# Altium schematic sheet 2.HOST INTERFACE.SchDoc
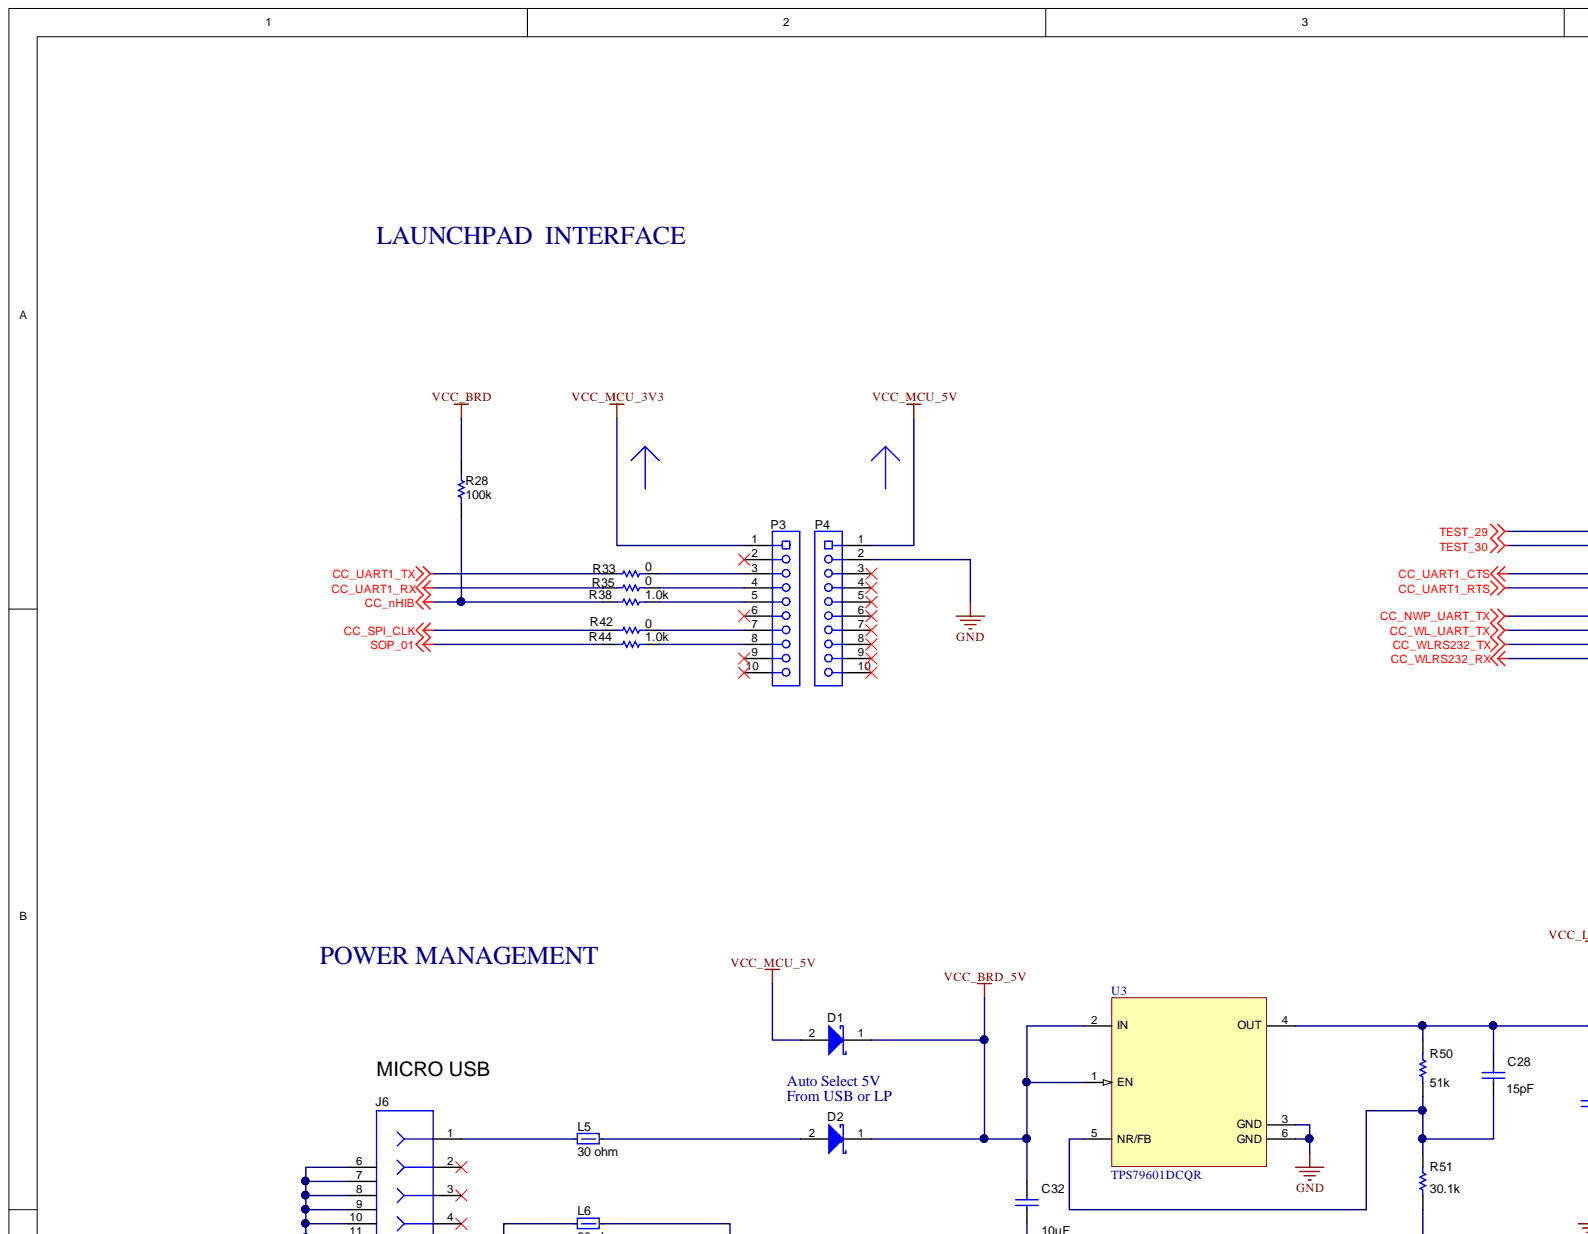
[diagram p0: 2.HOST INTERFACE.SchDoc, top left]
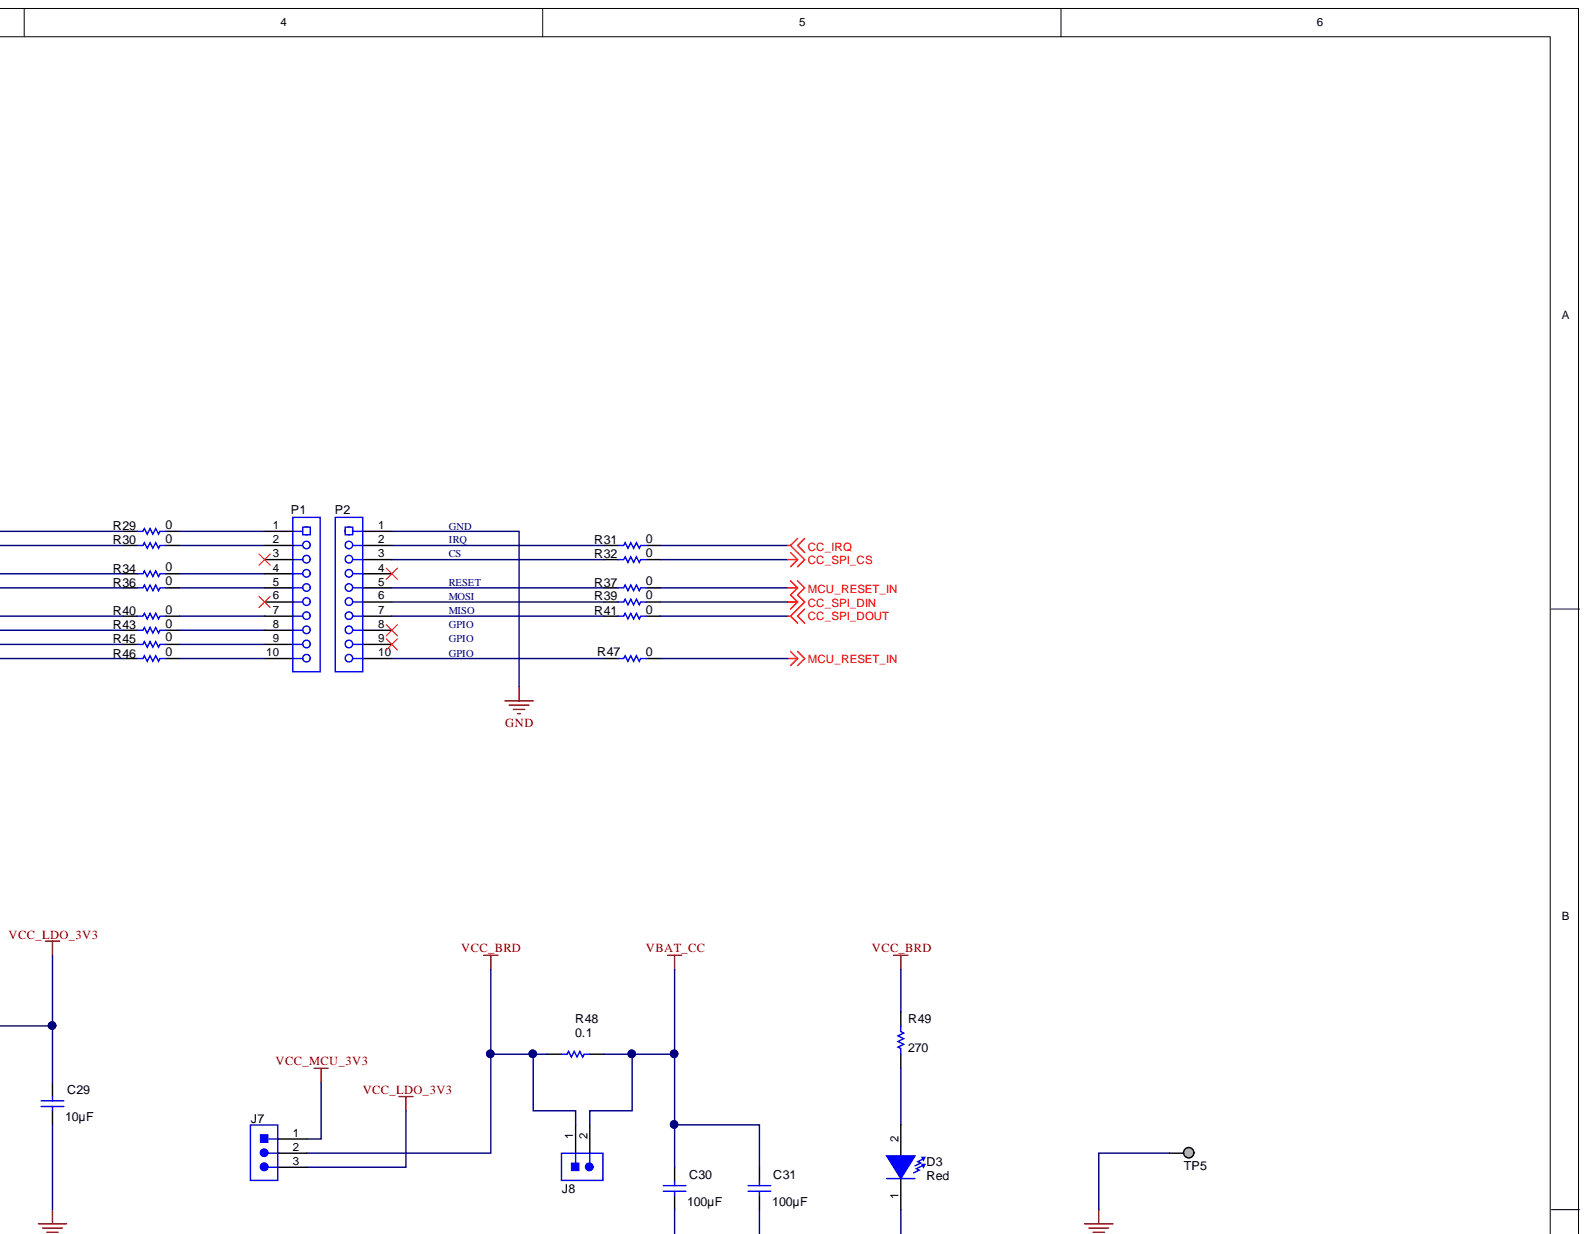
[diagram p1: 2.HOST INTERFACE.SchDoc, top right]
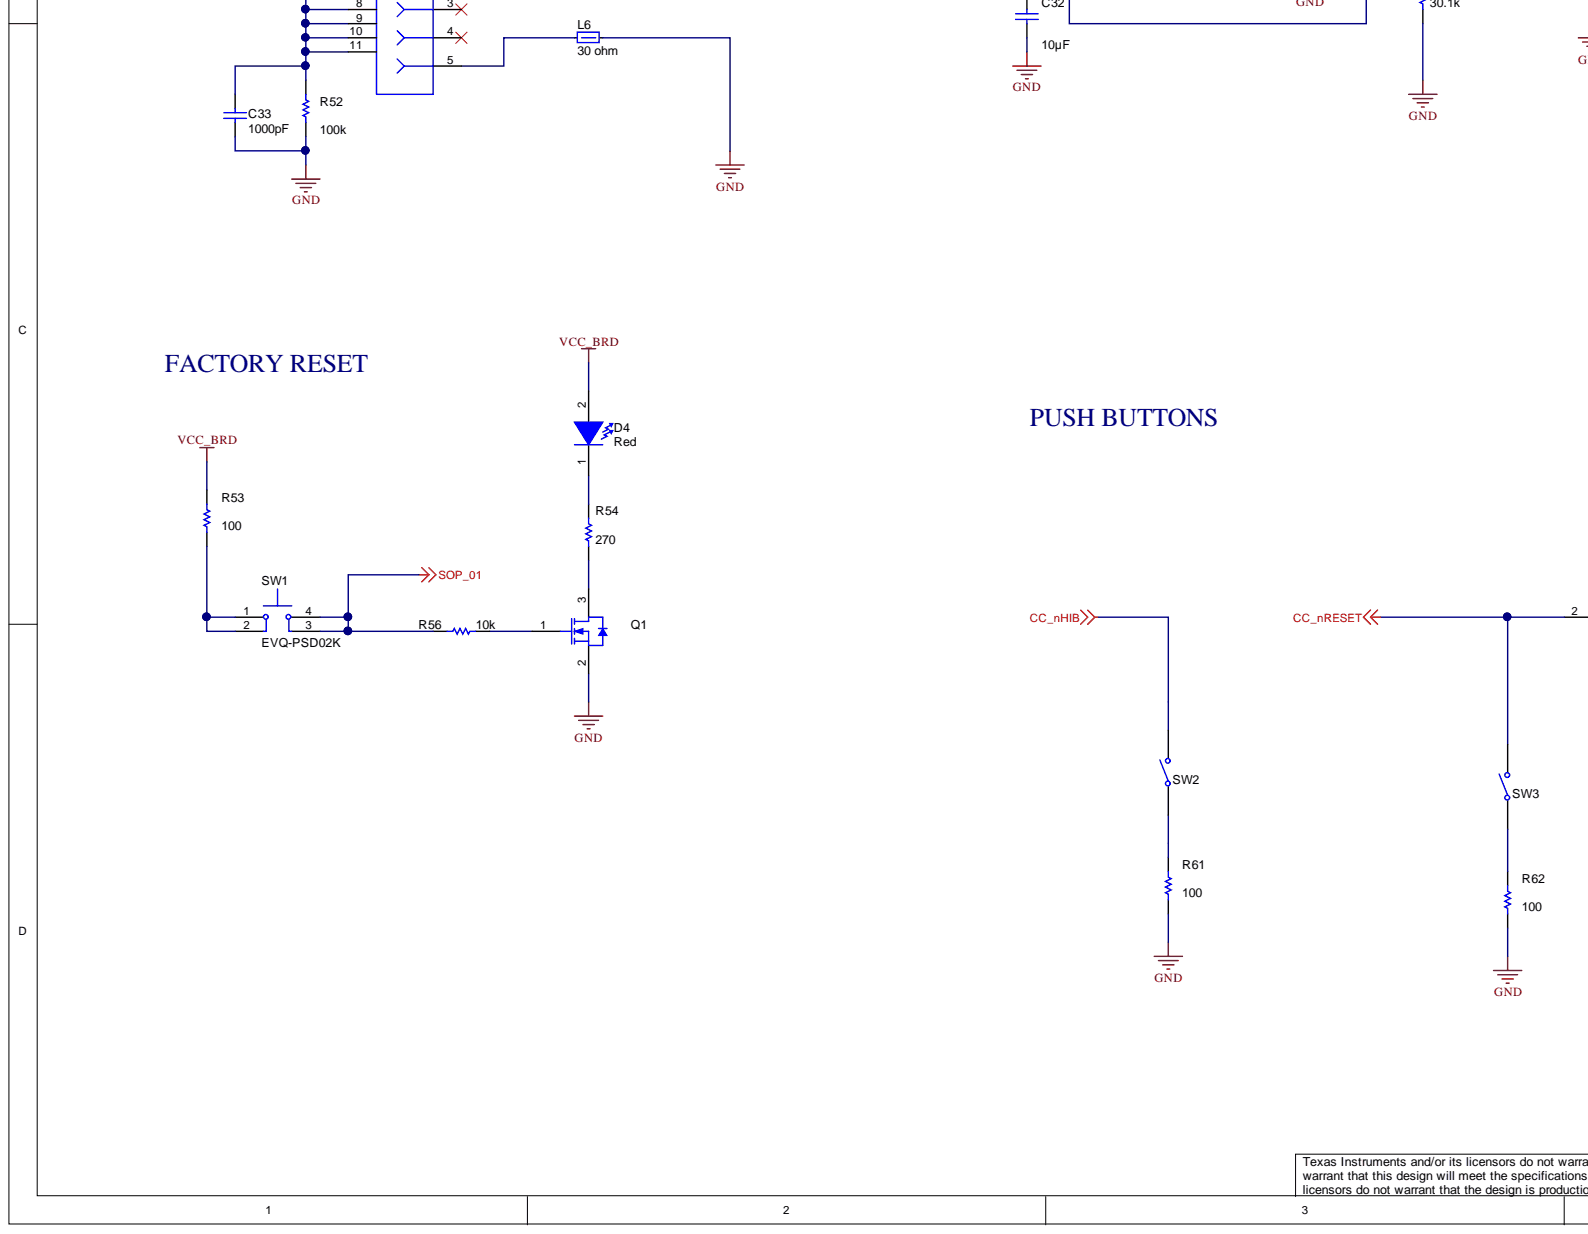
[diagram p2: 2.HOST INTERFACE.SchDoc, bottom left]
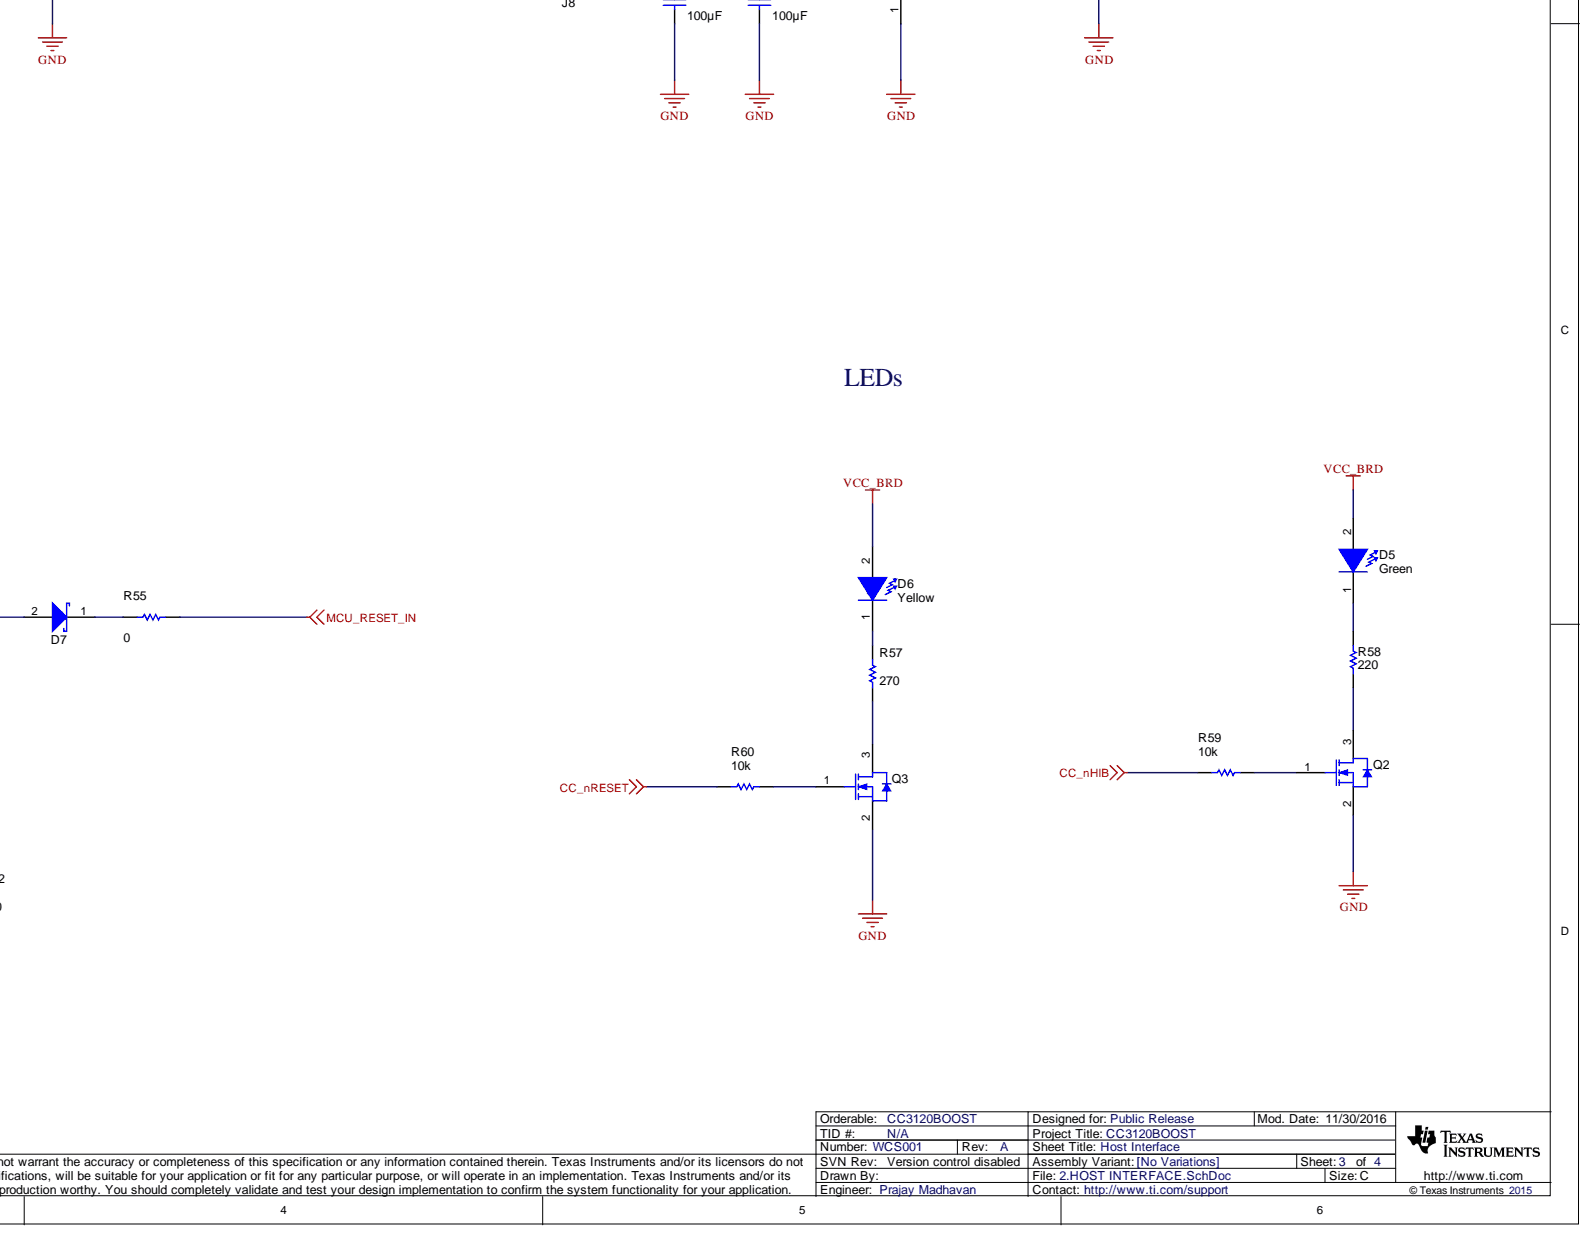
[diagram p3: 2.HOST INTERFACE.SchDoc, bottom right]

## Parts
C28  value 15pF  [0402]  (hidden comment: GRM1555C1H150JA01D)
C29  ValueDisplayed: 10µF  [0805_HV]  (hidden comment: GRM21BR61A106KE19L)
C30  ValueDisplayed: 100µF  [1206]  (hidden comment: GRM31CR60J107ME39L)
C31  ValueDisplayed: 100µF  [1206]  (hidden comment: GRM31CR60J107ME39L)
C32  ValueDisplayed: 10µF  [0805_HV]  (hidden comment: GRM21BR61A106KE19L)
C33  value 1000pF  [0603]  (hidden comment: GRM188R61H102KA01D)
D1  MBR130T1G  [SOD-123]  (value not drawn)
D2  MBR130T1G  [SOD-123]  (value not drawn)
D3  value Red  [SML-31_Red]  (hidden comment: SML-311UTT86)
D4  value Red  Color: Red  [WL-SMCW_RED]  (hidden comment: 150060RS75000)
D5  value Green  Color: Green  [WL-SMCW_GREEN]  (hidden comment: 150060GS75000)
D6  value Yellow  [WL-SMCW_YELLOW]  (hidden comment: 150060YS75000)
D7  CD0603-B00340  [Diode_0603]  (value not drawn)
J6  105017-0001  [CONN_105017-0001]  (value not drawn)
J7  TSW-103-07-G-S  [TSW-103-07-G-S]  (value not drawn)
J8  HMTSW-102-07-G-S-240  [SAMTEC_HMTSW-102-07-G-S-240]  (value not drawn)
L5  value 30 ohm  [0805_HV]  (hidden comment: MMZ2012R300A)
L6  value 30 ohm  [0805_HV]  (hidden comment: MMZ2012R300A)
P1  SSW-110-23-F-S  [CONN_SSW-110-23-F-S]  (value not drawn)
P2  SSW-110-23-F-S  [CONN_SSW-110-23-F-S]  (value not drawn)
P3  SSW-110-23-F-S  [CONN_SSW-110-23-F-S]  (value not drawn)
P4  SSW-110-23-F-S  [CONN_SSW-110-23-F-S]  (value not drawn)
Q1  BSS138  [SOT-23]  (value not drawn)
Q2  BSS138  [SOT-23]  (value not drawn)
Q3  BSS138  [SOT-23]  (value not drawn)
R28  value 100k  [0603]  (hidden comment: CRCW0603100KFKEA)
R29  value 0  [0402]  (hidden comment: ERJ-2GE0R00X)
R30  value 0  [0402]  (hidden comment: ERJ-2GE0R00X)
R31  value 0  [0402]  (hidden comment: ERJ-2GE0R00X)
R32  value 0  [0402]  (hidden comment: ERJ-2GE0R00X)
R33  value 0  [0402]  (hidden comment: ERJ-2GE0R00X)
R34  value 0  [0402]  (hidden comment: ERJ-2GE0R00X)
R35  value 0  [0402]  (hidden comment: ERJ-2GE0R00X)
R36  value 0  [0402]  (hidden comment: ERJ-2GE0R00X)
R37  value 0  [0402]  (hidden comment: ERJ-2GE0R00X)
R38  value 1.0k  [0402]  (hidden comment: CRCW04021K00JNED)
R39  value 0  [0402]  (hidden comment: ERJ-2GE0R00X)
R40  value 0  [0402]  (hidden comment: ERJ-2GE0R00X)
R41  value 0  [0402]  (hidden comment: ERJ-2GE0R00X)
R42  value 0  [0402]  (hidden comment: ERJ-2GE0R00X)
R43  value 0  [0402]  (hidden comment: ERJ-2GE0R00X)
R44  value 1.0k  [0402]  (hidden comment: CRCW04021K00JNED)
R45  value 0  [0402]  (hidden comment: ERJ-2GE0R00X)
R46  value 0  [0402]  (hidden comment: ERJ-2GE0R00X)
R47  value 0  [0402]  (hidden comment: ERJ-2GE0R00X)
R48  value 0.1  Res_ohm: 0.1  [0805_HV]  (hidden comment: CRM0805-FX-R100ELF)
R49  value 270  [0603]  (hidden comment: CRCW0603270RJNEA)
R50  value 51k  [0402]  (hidden comment: CRCW040251K0JNED)
R51  value 30.1k  [0402]  (hidden comment: CRCW040230K1FKED)
R52  value 100k  [0402]  (hidden comment: CRCW0402100KJNED)
R53  value 100  [0402]  (hidden comment: ERJ-2RKF1000X)
R54  value 270  [0603]  (hidden comment: CRCW0603270RJNEA)
R55  value 0  [0603]  (hidden comment: ERJ-3GEY0R00V)
R56  value 10k  [0402]  (hidden comment: CRCW040210K0JNED)
R57  value 270  [0603]  (hidden comment: CRCW0603270RJNEA)
R58  value 220  [0603]  (hidden comment: CRCW0603220RJNEA)
R59  value 10k  [0402]  (hidden comment: CRCW040210K0JNED)
R60  value 10k  [0402]  (hidden comment: CRCW040210K0JNED)
R61  value 100  [0402]  (hidden comment: ERJ-2RKF1000X)
R62  value 100  [0402]  (hidden comment: ERJ-2RKF1000X)
SW1  EVQ-PSD02K  [SW_EVQ-PSD02K]
SW2  MJTP1117  [SW_MJTP1117]  (value not drawn)
SW3  MJTP1117  [SW_MJTP1117]  (value not drawn)
TP5  TESTPOINT  [TP_H045P075]  (value not drawn)  (no BOM)
U3  TPS79601DCQR  [DCQ0006A_N]

## Nets
CC_IRQ: R31.2
CC_NHIB: R28.1 R38.1 R59.1 SW2.2
CC_NRESET: D7.2 R60.1 SW3.2
CC_NWP_UART_TX: R40.1
CC_SPI_CLK: R42.1
CC_SPI_CS: R32.2
CC_SPI_DIN: R39.2
CC_SPI_DOUT: R41.2
CC_UART1_CTS: R34.1
CC_UART1_RTS: R36.1
CC_UART1_RX: R35.1
CC_UART1_TX: R33.1
CC_WL_UART_TX: R43.1
CC_WLRS232_RX: R46.1
CC_WLRS232_TX: R45.1
GND: C29.2 C30.2 C31.2 C32.2 C33.2 D3.1 L6.1 P2.1 P4.2 Q1.2 Q2.2 Q3.2 R51.1 R52.2 R61.1 R62.1 TP5.1 U3.3(GND) U3.6(GND)
MCU_RESET_IN: R37.2 R47.2 R55.2
SOP_01: R44.1 R56.1 SW1.3 SW1.4
TEST_29: R29.1
TEST_30: R30.1
VBAT_CC: C30.1 C31.1 J8.2 R48.2
VCC_BRD: D4.2 D5.2 D6.2 J7.2 J8.1 R28.2 R48.1 R49.1 R53.2
VCC_BRD_5V: C32.1 D1.1 D2.1 U3.1(EN) U3.2(IN)
VCC_LDO_3V3: C28.1 C29.1 J7.3 R50.2 U3.4(OUT)
VCC_MCU_3V3: J7.1 P3.1
VCC_MCU_5V: D1.2 P4.1
NetC28_2: C28.2 R50.1 R51.2 U3.5(NR/FB)
NetC33_1: C33.1 J6.6 J6.7 J6.8 J6.9 J6.10 J6.11 R52.1
NetD2_2: D2.2 L5.2
NetD3_2: D3.2 R49.2
NetD4_1: D4.1 R54.1
NetD5_1: D5.1 R58.1
NetD6_1: D6.1 R57.1
NetD7_1: D7.1 R55.1
NetJ6_1: J6.1 L5.1
NetJ6_5: J6.5 L6.2
NetP1_1: P1.1 R29.2
NetP1_2: P1.2 R30.2
NetP1_4: P1.4 R34.2
NetP1_5: P1.5 R36.2
NetP1_7: P1.7 R40.2
NetP1_8: P1.8 R43.2
NetP1_9: P1.9 R45.2
NetP1_10: P1.10 R46.2
NetP2_2: P2.2 R31.1
NetP2_3: P2.3 R32.1
NetP2_5: P2.5 R37.1
NetP2_6: P2.6 R39.1
NetP2_7: P2.7 R41.1
NetP2_10: P2.10 R47.1
NetP3_3: P3.3 R33.2
NetP3_4: P3.4 R35.2
NetP3_5: P3.5 R38.2
NetP3_7: P3.7 R42.2
NetP3_8: P3.8 R44.2
NetQ1_1: Q1.1 R56.2
NetQ1_3: Q1.3 R54.2
NetQ2_1: Q2.1 R59.2
NetQ2_3: Q2.3 R58.2
NetQ3_1: Q3.1 R60.2
NetQ3_3: Q3.3 R57.2
NetR53_1: R53.1 SW1.1 SW1.2
NetR61_2: R61.2 SW2.1
NetR62_2: R62.2 SW3.1

Not connected: J6 2 3 4; P1 3 6; P2 4 8 9; P3 2 6 9 10; P4 3 4 5 6 7 8 9 10

## Library data of the parts
C28 = GRM1555C1H150JA01D: CAP, CERM, 15 pF, 50 V, +/- 5%, C0G/NP0, 0402; Manufacturer: MuRata; Cap_F: 0.000000000015; CompType: Capacitor; Polarized: N; TaMax_C: 125; TaMin_C: -55; Tolerance_%: 5; U/M: EA; Value1: Unknown; Value2: 50V; VDC_V: 50; Cap_Case: 0402; Component Kind: Standard; ComponentLink1Description: Datasheet link; ComponentLink1URL: http://search.murata.co.jp/Ceramy/PnsearchViewAction.do?HnOFG=ON&HnNFG=ON&SEL_CNT=50&sLang=en&sKey=GRM1555C1H150JA01D&x=9&y=9&search=startwith; LatestRevisionDate: 2015-02-23; Library Name: Capacitor_Ceramic.IntLib; MountType: SMT; PackageReference: 0402; PartNumber: GRM1555C1H150JA01D; Published: 2008-06-19; RoHS: Y; Signal Integrity: Cap; Simulation: Cap; Supplier 1: Mouser; Supplier Part Number 1: 81-GRM1555C1H150JA1D; SymbolVersion: 2008-07-09; Technology: Ceramic; TempCo: C0G/NP0; ValueDisplayed: 15pF; Publisher: Texas Instruments
C29 C32 = GRM21BR61A106KE19L: CAP, CERM, 10 µF, 10 V, +/- 10%, X5R, 0805; Manufacturer: MuRata; Cap_Case: 0805; Cap_F: 0.00001; CompType: Capacitor; ESR_ohm: 0.002; Polarized: N; TaMax_C: 85; TaMin_C: -55; Tolerance_%: 10; U/M: EA; Value: 10uF; Value1: 0.002 ohm; Value2: 10V; Component Kind: Standard; ComponentLink1Description: Datasheet link; ComponentLink1URL: http://search.murata.co.jp/Ceramy/PnsearchViewAction.do?HnOFG=ON&HnNFG=ON&SEL_CNT=50&sLang=en&sKey=GRM21BR61A106KE19L&x=9&y=9&search=startwith; LatestRevisionDate: 2015-02-23; Library Name: Capacitor_Ceramic.IntLib; MountType: SMT; PackageReference: 0805; PartNumber: GRM21BR61A106KE19L; Published: 2008-06-19; RoHS: Y; Signal Integrity: Cap; Simulation: Cap; Supplier 1: Digi-Key; Supplier 2: Mouser; Supplier 3: Farnell; Supplier Part Number 1: 490-1709-1-ND; Supplier Part Number 2: 81-GRM21BR61A106KE19; Supplier Part Number 3: 1828805; SymbolVersion: 2008-07-09; Technology: Ceramic; TempCo: X5R; VDC_V: 10; Publisher: Texas Instruments
C30 C31 = GRM31CR60J107ME39L: CAP, CERM, 100 µF, 6.3 V, +/- 20%, X5R, 1206; Manufacturer: MuRata; Cap_F: 0.0001; CompType: Capacitor; ESR_ohm: 0.003; Polarized: N; TaMax_C: 85; TaMin_C: -55; Tolerance_%: 20; U/M: EA; Value: 100uF; Value1: 0.003 ohm; Value2: 6.3V; VDC_V: 6.3; Cap_Case: 1206; Component Kind: Standard; ComponentLink1Description: Datasheet link; ComponentLink1URL: http://search.murata.co.jp/Ceramy/PnsearchViewAction.do?HnOFG=ON&HnNFG=ON&SEL_CNT=50&sLang=en&sKey=GRM31CR60J107ME39L&x=9&y=9&search=startwith; LatestRevisionDate: 2015-02-23; Library Name: Capacitor_Ceramic.IntLib; MountType: SMT; PackageReference: 1206; PartNumber: GRM31CR60J107ME39L; Published: 2008-06-19; RoHS: Y; Signal Integrity: Cap; Simulation: Cap; Supplier 1: Digi-Key; Supplier 2: Mouser; Supplier 3: Farnell; Supplier Part Number 1: 490-4539-1-ND; Supplier Part Number 2: 81-GRM31CR60J107ME9L; Supplier Part Number 3: 1735535; SymbolVersion: 2008-07-09; Technology: Ceramic; TempCo: X5R; Publisher: Texas Instruments
C33 = GRM188R61H102KA01D: CAP, CERM, 1000 pF, 50 V, +/- 10%, X5R, 0603; Manufacturer: MuRata; Cap_F: 0.000000001; CompType: Capacitor; Polarized: N; TaMax_C: 85; TaMin_C: -55; Tolerance_%: 10; U/M: EA; Value1: Unknown; Value2: 50V; VDC_V: 50; Cap_Case: 0603; Component Kind: Standard; ComponentLink1Description: Datasheet link; ComponentLink1URL: http://search.murata.co.jp/Ceramy/PnsearchViewAction.do?HnOFG=ON&HnNFG=ON&SEL_CNT=50&sLang=en&sKey=GRM188R61H102KA01D&x=9&y=9&search=startwith; LatestRevisionDate: 2014-12-18; Library Name: Capacitor_Ceramic.IntLib; MountType: SMT; PackageReference: 0603; PartNumber: GRM188R61H102KA01D; Published: 2008-06-19; RoHS: Y; Signal Integrity: Cap; Simulation: Cap; Supplier 1: Digi-Key; Supplier 2: Mouser; Supplier Part Number 1: GRM188R61H102KA01D-ND; Supplier Part Number 2: 81-GRM188R61H102KA1D; SymbolVersion: 2008-07-09; Technology: Ceramic; TempCo: X5R; ValueDisplayed: 1000pF; Publisher: Texas Instruments
D1 D2 = MBR130T1G: Diode, Schottky, 30 V, 1 A, SOD-123; Manufacturer: ON Semiconductor; Io_A: 1; RJC_CperW: 108; TJmax_C: 125; U/M: EA; Value: 30V; Value1: 1A; Value2: 0.47V; Vf_V: 0.47; Vr_V: 30; Component Kind: Standard; ComponentLink1Description: Datasheet link; ComponentLink1URL: http://www.onsemi.com/pub_link/Collateral/MBR130T1-D.PDF; CompType: Diode; LatestRevisionDate: 2015-02-19; Library Name: Diode.IntLib; MountType: SMT; PackageReference: SOD-123; PartNumber: MBR130T1G; Published: 2008-06-26; RoHS: Y; Signal Integrity: Diode; Simulation: mbr130prl; Supplier 1: Digi-Key; Supplier 2: Mouser; Supplier 3: Farnell; Supplier Part Number 1: MBR130T1GOSCT-ND; Supplier Part Number 2: 863-MBR130T1G; Supplier Part Number 3: 1431045; SymbolVersion: 2008-12-08; Type: Schottky; Publisher: Texas Instruments
D3 = SML-311UTT86: LED, Red, SMD; Manufacturer: Rohm; Io_A: 0.002; Type: AlInGaP; U/M: EA; Value1: W; Value2: 1.8V; Vf_V: 1.8; Color: red; Component Kind: Standard; ComponentLink1Description: Datasheet link; ComponentLink1URL: http://rohmfs.rohm.com/en/products/databook/datasheet/opto/led/chip_mono/sml-31.pdf; CompType: LED; LatestRevisionDate: 2015-06-04; Library Name: LED.IntLib; MountType: SMT; PackageReference: LED, 1.6x.8x.8mm; PartNumber: SML-311UTT86; Published: 2008-07-11; RoHS: Y; Signal Integrity: Diode; Supplier 1: Digi-Key; Supplier 2: Mouser; Supplier 3: Farnell; Supplier Part Number 1: 511-1304-1-ND; Supplier Part Number 2: 755-SML-311UTT86; Supplier Part Number 3: 1685068; SymbolVersion: 2008-11-06; Publisher: Texas Instruments
D4 = 150060RS75000: LED, Red, SMD; Manufacturer: Wurth Elektronik; Angle: 140; Io_A: 0.03; Power_TotalModule: 0.072; Tjmax_C: 85; Type: AlInGaP; U/M: EA; Value1: 0.072W; Value2: 2V; Vf_V: 2; Vr_V: 5; Component Kind: Standard; ComponentLink1Description: Datasheet link; ComponentLink1URL: http://katalog.we-online.de/led/datasheet/150060RS75000.pdf; CompType: LED; LatestRevisionDate: 2015-11-19; Library Name: LED.IntLib; MountType: SMT; PackageReference: LED_0603; PartNumber: 150060RS75000; Published: 2008-07-11; RoHS: Y; Signal Integrity: Diode; Supplier 1: Digi-Key; Supplier 2: Mouser; Supplier 3: Farnell; Supplier Part Number 1: 732-4978-1-ND; Supplier Part Number 2: 710-150060RS75000; Supplier Part Number 3: 2322071; SymbolVersion: 2008-11-06; Publisher: Texas Instruments
D5 = 150060GS75000: LED, Green, SMD; Manufacturer: Wurth Elektronik; Angle: 140; Io_A: 0.02; Tjmax_C: 85; Type: InGaN; U/M: EA; Value1: W; Value2: 3.2V; Vf_V: 3.2; Component Kind: Standard; ComponentLink1Description: Datasheet link; ComponentLink1URL: http://katalog.we-online.de/led/datasheet/150060GS75000.pdf; CompType: LED; LatestRevisionDate: 2015-11-19; Library Name: LED.IntLib; MountType: SMT; PackageReference: LED_0603; PartNumber: 150060GS75000; Published: 2008-07-11; RoHS: Y; Signal Integrity: Diode; Supplier 1: Digi-Key; Supplier 2: Mouser; Supplier 3: Farnell; Supplier Part Number 1: 732-4971-1-ND; Supplier Part Number 2: 710-150060GS75000; Supplier Part Number 3: 2322070; SymbolVersion: 2008-11-06; Publisher: Texas Instruments
D6 = 150060YS75000: LED, Yellow, SMD; Manufacturer: Wurth Elektronik eiSos; Io_A: 0.02; Type: AlInGaP; U/M: EA; Value1: W; Value2: 2V; Vf_V: 2; Color: yellow; Component Kind: Standard; ComponentLink1Description: Datasheet link; ComponentLink1URL: http://katalog.we-online.de/led/datasheet/150060YS75000.pdf; CompType: LED; LatestRevisionDate: 2015-03-19; Library Name: LED.IntLib; MountType: SMT; PackageReference: LED_0603; PartNumber: 150060YS75000; Published: 2008-07-11; RoHS: Y; Signal Integrity: Diode; Supplier 1: Digi-Key; Supplier Part Number 1: 732-4981-1-ND; SymbolVersion: 2008-11-06; Publisher: Texas Instruments
D7 = CD0603-B00340: Diode, Schottky, 45 V, 0.03 A, 0603 Diode; Manufacturer: Bourns; Io_A: 0.03; TJmax_C: 125; U/M: EA; Value: 45V; Value1: 0.03A; Value2: 0.37V; Vf_V: 0.37; Vr_V: 45; Component Kind: Standard; ComponentLink1Description: Datasheet link; ComponentLink1URL: http://www.bourns.com/pdfs/SBCD0603_1005.pdf; CompType: Diode; LatestRevisionDate: 2015-02-19; Library Name: Diode.IntLib; MountType: SMT; PackageReference: 0603 Diode; PartNumber: CD0603-B00340; Published: 2008-06-26; RoHS: Y; Signal Integrity: Diode; Supplier 1: Mouser; Supplier 2: Farnell; Supplier Part Number 1: 652-CD0603-B00340; Supplier Part Number 2: 1456534; SymbolVersion: 2008-12-08; Type: Schottky; Publisher: Texas Instruments
J6 = 105017-0001: Connector, Receptacle, Micro-USB Type B, R/A, Bottom Mount SMT; Manufacturer: Molex; SymbolCreator: a0412235; U/M: EA; Component Kind: Standard; ComponentLink1Description: Datasheet Link; ComponentLink1URL: http://www.molex.com/pdm_docs/sd/1050170001_sd.pdf; CompType: Receptacle; LatestRevisionDate: 2015-06-05; Library Name: Connectors_Molex.IntLib; MountType: SMT; PackageReference: Micro USB receptacle; PartNumber: 105017-0001; RoHS: Y; Supplier 1: Digi-Key; Supplier 2: Mouser; Supplier 3: Farnell; Supplier Part Number 1: WM1399CT-ND; Supplier Part Number 2: 538-105017-0001; Supplier Part Number 3: 1847433; SymbolVersion: 2012-12-19; Publisher: Texas Instruments
J7 = TSW-103-07-G-S: Header, 100mil, 3x1, Gold, TH; Manufacturer: Samtec; SymbolCreator: a0799628; U/M: EA; ClassName: Header; Component Kind: Standard; ComponentLink1Description: Datasheet Link; ComponentLink1URL: http://samtec.com/ftppub/pdf/TSW_TH.PDF; CompType: Header; LatestRevisionDate: 2015-03-20; Library Name: Connectors_Samtec.IntLib; MountType: TH; PackageReference: 3x1 Header; PartNumber: TSW-103-07-G-S; RoHS: Y; Supplier 1: Digi-Key; Supplier Part Number 1: SAM1029-03-ND; SymbolVersion: 2013-03-28; Publisher: Texas Instruments
J8 = HMTSW-102-07-G-S-240: Header, 100mil, 2x1, Gold, TH; Manufacturer: Samtec; SymbolCreator: a0412235; U/M: EA; ClassName: Header; Component Kind: Standard; ComponentLink1Description: Datasheet Link; ComponentLink1URL: http://www.samtec.com/documents/webfiles/cpdf/HMTSW-XXX-XX-X-X-XXXX-XX-XX-MKT1.pdf; CompType: Header; LatestRevisionDate: 2015-03-20; Library Name: Connectors_Samtec.IntLib; MountType: TH; PackageReference: Header, 2.54mm, 2x1, TH; PartNumber: HMTSW-102-07-G-S-240; RoHS: Y; Supplier 1: Digi-Key; Supplier Part Number 1: HMTSW-102-07-G-S-240-ND; SymbolVersion: 2013-10-31; Publisher: Texas Instruments
L5 L6 = MMZ2012R300A: Ferrite Bead, 30 ohm @ 100 MHz, 1.5 A, 0805; Manufacturer: TDK; DCR_ohm: 0.05; Imax_A: 1.5; Value1: 0.05 ohm; Value2: 1.5A; ZTyp@100MHz_Ohm: 30; Component Kind: Standard; ComponentLink1Description: Datasheet link; ComponentLink1URL: http://www.tdk.co.jp/tefe02/e9412_mmz.pdf; CompType: Ferrite Bead; LatestRevisionDate: 2015-03-14; Library Name: FerriteBead.IntLib; MountType: SMT; PackageReference: 0805; PartNumber: MMZ2012R300A; Published: 2014-04-30; RoHS: Y; Supplier 1: Digi-Key; Supplier 2: Mouser; Supplier 3: Farnell; Supplier Part Number 1: 445-2199-1-ND; Supplier Part Number 2: 810-MMZ2012R300A; Supplier Part Number 3: 1669723; SymbolVersion: 2009-06-02; Publisher: Texas Instruments
P1-P4 = SSW-110-23-F-S: Connector, Receptacle, 100mil, 10x1, Gold plated, TH; Manufacturer: Samtec; SymbolCreator: a0411947; U/M: EA; Component Kind: Standard; ComponentLink1Description: Datasheet Link; ComponentLink1URL: http://www.samtec.com/technical-specifications/default.aspx?SeriesMaster=SSW; CompType: Connector; LatestRevisionDate: 2015-06-02; Library Name: Connectors_Samtec.IntLib; MountType: TH; PackageReference: 10x1 Receptacle; PartNumber: SSW-110-23-F-S; RoHS: Y; SymbolVersion: 2013-12-22; Publisher: Texas Instruments
Q1-Q3 = BSS138: MOSFET, N-CH, 50 V, 0.22 A, SOT-23; Alternate Manufacturer: None; Manufacturer: Fairchild Semiconductor; ChType: N; IdsMax_A: 0.22; QgTyp_nC: 1.7; Rdson45_ohm: 6; U/M: EA; Value: 50V; Value1: 6 ohm; Value2: 1.7 nC; VdsMax_V: 50; Vgs_V: 20; Component Kind: Standard; ComponentLink1Description: Datasheet link; ComponentLink1URL: http://www.fairchildsemi.com/ds/BS/BSS138.pdf; CompType: MOSFET; LatestRevisionDate: 2015-06-05; LatestRevisionNote: Added parts.; Library Name: FETs.IntLib; MountType: SMT; PackageReference: SOT-23; PartNumber: BSS138; Published: 2008-06-19; RoHS: Y; Supplier 1: Digi-Key; Supplier 2: Mouser; Supplier 3: Farnell; Supplier Part Number 1: BSS138CT-ND; Supplier Part Number 2: 512-BSS138; Supplier Part Number 3: 9845330; SymbolVersion: 2008-07-28; Publisher: Texas Instruments
R28 = CRCW0603100KFKEA: RES, 100 k, 1%, 0.1 W, 0603; Manufacturer: Vishay-Dale; CompType: Resistor; EIA_Code: E96; Power_W: 0.1; Res_ohm: 100000; TempCo: 100 ppm/C; Tolerance_%: 1; U/M: EA; Value2: 0.1W; VDC_V: 75; Component Kind: Standard; ComponentLink1Description: Datasheet link; ComponentLink1URL: http://www.vishay.com/docs/20035/dcrcwe3.pdf; LatestRevisionDate: 2015-06-03; Library Name: Resistor_0603.IntLib; MountType: SMT; PackageReference: 0603; PartNumber: CRCW0603100KFKEA; Published: 2008-06-26; RoHS: Y; Signal Integrity: Resistor; Simulation: Resistor; Supplier 1: Digi-Key; Supplier 2: Mouser; Supplier 3: Farnell; Supplier Part Number 1: 541-100KHCT-ND; Supplier Part Number 2: 71-CRCW0603-100K-E3; Supplier Part Number 3: 1469649; SymbolVersion: 2009-05-29; Technology: Thick Film; Value1: 1%; Value_Code: 100k; ValueDisplayed: 100k; Publisher: Texas Instruments
R29-R37 R39-R43 R45-R47 = ERJ-2GE0R00X: RES, 0, 5%, 0.063 W, 0402; Manufacturer: Panasonic; CompType: Resistor; EIA_Code: E24; Power_W: 0.063; TempCo: 600 ppm/C; Tolerance_%: 5; U/M: EA; Value2: 0.063W; Value_Code: 0000; VDC_V: 50; Component Kind: Standard; ComponentLink1Description: Datasheet link; ComponentLink1URL: http://www.panasonic.com/industrial/components/pdf/AOA0000CE1.pdf; LatestRevisionDate: 2015-02-16; Library Name: Resistor_0402.IntLib; MountType: SMT; PackageReference: 0402; PartNumber: ERJ-2GE0R00X; Published: 2008-06-26; Res_ohm: 0; RoHS: Y; Signal Integrity: Resistor; Simulation: Resistor; Supplier 1: Digi-Key; Supplier 2: Mouser; Supplier 3: Farnell; Supplier Part Number 1: P0.0JCT-ND; Supplier Part Number 2: 667-ERJ-2GE0R00X; Supplier Part Number 3: 2059190; SymbolVersion: 2009-05-29; Technology: Thick Film; Value1: 5%; ValueDisplayed: 0; Publisher: Texas Instruments
R38 R44 = CRCW04021K00JNED: RES, 1.0 k, 5%, 0.063 W, 0402; Manufacturer: Vishay-Dale; CompType: Resistor; EIA_Code: E24; Power_W: 0.063; Res_ohm: 1000; TempCo: 200 ppm/C; Tolerance_%: 5; U/M: EA; Value2: 0.063W; Value_Code: 1k00; VDC_V: 50; Component Kind: Standard; ComponentLink1Description: Datasheet link; ComponentLink1URL: http://www.vishay.com/docs/20035/dcrcwe3.pdf; LatestRevisionDate: 2015-02-16; Library Name: Resistor_0402.IntLib; MountType: SMT; PackageReference: 0402; PartNumber: CRCW04021K00JNED; Published: 2008-06-26; RoHS: Y; Signal Integrity: Resistor; Simulation: Resistor; Supplier 1: Digi-Key; Supplier 2: Mouser; Supplier 3: Farnell; Supplier Part Number 1: 541-1.0KJCT-ND; Supplier Part Number 2: 71-CRCW0402J-1.0K-E3; Supplier Part Number 3: 1514656; SymbolVersion: 2009-05-29; Technology: Thick Film; Value1: 5%; ValueDisplayed: 1.0k; Publisher: Texas Instruments
R48 = CRM0805-FX-R100ELF: RES, 0.1, 1%, 0.25 W, 0805; Manufacturer: Bourns; CompType: Resistor; EIA_Code: E96; Power_W: 0.25; TempCo: 150 ppm/C; Tolerance_%: 1; U/M: EA; Value2: 0.25W; Value_Code: R100; VDC_V: 0; Component Kind: Standard; ComponentLink1Description: Datasheet link; ComponentLink1URL: http://www.bourns.com/data/global/pdfs/CRM0805_1206_2010.pdf; LatestRevisionDate: 2015-01-29; Library Name: Resistor_0805.IntLib; MountType: SMT; PackageReference: 0805; PartNumber: CRM0805-FX-R100ELF; Published: 2008-06-26; RoHS: Y; Signal Integrity: Resistor; Simulation: Resistor; Supplier 1: Mouser; Supplier 2: Farnell; Supplier Part Number 1: 652-CRM0805FXR100ELF; Supplier Part Number 2: 1865233; SymbolVersion: 2009-05-29; Technology: Film; Value1: 1%; ValueDisplayed: 0.1; Publisher: Texas Instruments
R49 R54 R57 = CRCW0603270RJNEA: RES, 270, 5%, 0.1 W, 0603; Manufacturer: Vishay-Dale; CompType: Resistor; EIA_Code: E24; Power_W: 0.1; TempCo: 200 ppm/C; Tolerance_%: 5; U/M: EA; Value2: 0.1W; Value_Code: 270R; VDC_V: 75; Component Kind: Standard; ComponentLink1Description: Datasheet link; ComponentLink1URL: http://www.vishay.com/docs/20035/dcrcwe3.pdf; LatestRevisionDate: 2014-12-16; Library Name: Resistor_0603.IntLib; MountType: SMT; PackageReference: 0603; PartNumber: CRCW0603270RJNEA; Published: 2008-06-26; Res_ohm: 270; RoHS: Y; Signal Integrity: Resistor; Simulation: Resistor; Supplier 1: Digi-Key; Supplier 2: Mouser; Supplier 3: Farnell; Supplier Part Number 1: 541-270GCT-ND; Supplier Part Number 2: 71-CRCW0603J-270-E3; Supplier Part Number 3: 1514748; SymbolVersion: 2009-05-29; Technology: Thick Film; Value1: 5%; ValueDisplayed: 270; Publisher: Texas Instruments
R50 = CRCW040251K0JNED: RES, 51 k, 5%, 0.063 W, 0402; Manufacturer: Vishay-Dale; CompType: Resistor; EIA_Code: E24; Power_W: 0.063; Res_ohm: 51000; TempCo: 200 ppm/C; Tolerance_%: 5; U/M: EA; Value2: 0.063W; Value_Code: 51k0; VDC_V: 50; Component Kind: Standard; ComponentLink1Description: Datasheet link; ComponentLink1URL: http://www.vishay.com/docs/20035/dcrcwe3.pdf; LatestRevisionDate: 2015-02-16; Library Name: Resistor_0402.IntLib; MountType: SMT; PackageReference: 0402; PartNumber: CRCW040251K0JNED; Published: 2008-06-26; RoHS: Y; Signal Integrity: Resistor; Simulation: Resistor; Supplier 1: Digi-Key; Supplier 2: Mouser; Supplier 3: Farnell; Supplier Part Number 1: 541-51KJCT-ND; Supplier Part Number 2: 71-CRCW0402J-51K-E3; Supplier Part Number 3: 1570680; SymbolVersion: 2009-05-29; Technology: Thick Film; Value1: 5%; ValueDisplayed: 51k; Publisher: Texas Instruments
R51 = CRCW040230K1FKED: RES, 30.1 k, 1%, 0.063 W, 0402; Manufacturer: Vishay-Dale; CompType: Resistor; EIA_Code: E96; Power_W: 0.063; Res_ohm: 30100; TempCo: 100 ppm/C; Tolerance_%: 1; U/M: EA; Value2: 0.063W; Value_Code: 30k1; VDC_V: 50; Component Kind: Standard; ComponentLink1Description: Datasheet link; ComponentLink1URL: http://www.vishay.com/docs/20035/dcrcwe3.pdf; LatestRevisionDate: 2015-02-16; Library Name: Resistor_0402.IntLib; MountType: SMT; PackageReference: 0402; PartNumber: CRCW040230K1FKED; Published: 2008-06-26; RoHS: Y; Signal Integrity: Resistor; Simulation: Resistor; Supplier 1: Digi-Key; Supplier 2: Mouser; Supplier 3: Farnell; Supplier Part Number 1: 541-30.1KLCT-ND; Supplier Part Number 2: 71-CRCW0402-30.1K-E3; Supplier Part Number 3: 1469704; SymbolVersion: 2009-05-29; Technology: Thick Film; Value1: 1%; ValueDisplayed: 30.1k; Publisher: Texas Instruments
R52 = CRCW0402100KJNED: RES, 100 k, 5%, 0.063 W, 0402; Manufacturer: Vishay-Dale; CompType: Resistor; EIA_Code: E24; Power_W: 0.063; Res_ohm: 100000; TempCo: 200 ppm/C; Tolerance_%: 5; U/M: EA; Value2: 0.063W; VDC_V: 50; Component Kind: Standard; ComponentLink1Description: Datasheet link; ComponentLink1URL: http://www.vishay.com/docs/20035/dcrcwe3.pdf; LatestRevisionDate: 2015-02-16; Library Name: Resistor_0402.IntLib; MountType: SMT; PackageReference: 0402; PartNumber: CRCW0402100KJNED; Published: 2008-06-26; RoHS: Y; Signal Integrity: Resistor; Simulation: Resistor; Supplier 1: Digi-Key; Supplier 2: Mouser; Supplier 3: Farnell; Supplier Part Number 1: 541-100KJCT-ND; Supplier Part Number 2: 71-CRCW0402J-100K-E3; Supplier Part Number 3: 1652738; SymbolVersion: 2009-05-29; Technology: Thick Film; Value1: 5%; Value_Code: 100k; ValueDisplayed: 100k; Publisher: Texas Instruments
R53 R61 R62 = ERJ-2RKF1000X: RES, 100, 1%, 0.1 W, 0402; Manufacturer: Panasonic; CompType: Resistor; EIA_Code: E96; Power_W: 0.1; TempCo: 100 ppm/C; Tolerance_%: 1; U/M: EA; Value2: 0.1W; Value_Code: 100R; VDC_V: 50; Component Kind: Standard; ComponentLink1Description: Datasheet link; ComponentLink1URL: http://industrial.panasonic.com/www-data/pdf/AOA0000/AOA0000CE2.pdf; LatestRevisionDate: 2015-02-16; Library Name: Resistor_0402.IntLib; MountType: SMT; PackageReference: 0402; PartNumber: ERJ-2RKF1000X; Published: 2008-06-26; Res_ohm: 100; RoHS: Y; Signal Integrity: Resistor; Simulation: Resistor; Supplier 1: Digi-Key; Supplier 2: Mouser; Supplier 3: Farnell; Supplier Part Number 1: P100LCT-ND; Supplier Part Number 2: 667-ERJ-2RKF1000X; Supplier Part Number 3: 2304034; SymbolVersion: 2009-05-29; Technology: Thick Film; Value1: 1%; ValueDisplayed: 100; Publisher: Texas Instruments
R55 = ERJ-3GEY0R00V: RES, 0, 5%, 0.1 W, 0603; Manufacturer: Panasonic; CompType: Resistor; EIA_Code: E24; Power_W: 0.1; TempCo: 600 ppm/C; Tolerance_%: 5; U/M: EA; Value2: 0.1W; Value_Code: 0000; VDC_V: 50; Component Kind: Standard; ComponentLink1Description: Datasheet link; ComponentLink1URL: http://www.panasonic.com/industrial/components/pdf/AOA0000CE1.pdf; LatestRevisionDate: 2014-12-16; Library Name: Resistor_0603.IntLib; MountType: SMT; PackageReference: 0603; PartNumber: ERJ-3GEY0R00V; Published: 2008-06-26; Res_ohm: 0; RoHS: Y; Signal Integrity: Resistor; Simulation: Resistor; Supplier 1: Digi-Key; Supplier 2: Mouser; Supplier 3: Farnell; Supplier Part Number 1: P0.0GCT-ND; Supplier Part Number 2: 667-ERJ-3GEY0R00V; Supplier Part Number 3: 2059527; SymbolVersion: 2009-05-29; Technology: Thick Film; Value1: 5%; ValueDisplayed: 0; Publisher: Texas Instruments
R56 R59 R60 = CRCW040210K0JNED: RES, 10 k, 5%, 0.063 W, 0402; Manufacturer: Vishay-Dale; CompType: Resistor; EIA_Code: E24; Power_W: 0.063; Res_ohm: 10000; TempCo: 200 ppm/C; Tolerance_%: 5; U/M: EA; Value2: 0.063W; Value_Code: 10k0; VDC_V: 50; Component Kind: Standard; ComponentLink1Description: Datasheet link; ComponentLink1URL: http://www.vishay.com/docs/20035/dcrcwe3.pdf; Library Name: Resistor_0402.IntLib; MountType: SMT; PackageReference: 0402; PartNumber: CRCW040210K0JNED; Published: 2008-06-26; RoHS: Y; Signal Integrity: Resistor; Simulation: Resistor; Supplier 1: Digi-Key; Supplier 2: Mouser; Supplier 3: Farnell; Supplier Part Number 1: 541-10KJCT-ND; Supplier Part Number 2: 71-CRCW0402J-10K-E3; Supplier Part Number 3: 1514663; SymbolVersion: 2009-05-29; Technology: Thick Film; Value1: 5%; ValueDisplayed: 10k; Publisher: Texas Instruments
  R56: LatestRevisionDate: 2015-05-27
  R59 R60: LatestRevisionDate: 2015-02-16
R58 = CRCW0603220RJNEA: RES, 220, 5%, 0.1 W, 0603; Manufacturer: Vishay-Dale; AEC Category: Q200; CompType: Resistor; EIA_Code: E24; Power_W: 0.1; TempCo: 200 ppm/C; Tolerance_%: 5; U/M: EA; Value2: 0.1W; Value_Code: 220R; VDC_V: 75; AEC Qualified: ?; Component Kind: Standard; ComponentLink1Description: Datasheet link; ComponentLink1URL: http://www.vishay.com/docs/20035/dcrcwe3.pdf; LatestRevisionDate: 2015-08-22; Library Name: Resistor_0603.IntLib; MountType: SMT; PackageReference: 0603; PartNumber: CRCW0603220RJNEA; Published: 2008-06-26; Res_ohm: 220; RoHS: Y; Signal Integrity: Resistor; Simulation: Resistor; Supplier 1: Digi-Key; Supplier 2: Mouser; Supplier 3: Farnell; Supplier Part Number 1: 541-220GCT-ND; Supplier Part Number 2: 71-CRCW0603J-220-E3; Supplier Part Number 3: 1652858; SymbolVersion: 2009-05-29; Technology: Thick Film; Value1: 5%; ValueDisplayed: 220; Publisher: Texas Instruments
SW1 = EVQ-PSD02K: Switch, Tactile, SPST-NO, SMT; Manufacturer: Panasonic; CurrentRating_mA: 50; PositionCount: 1; SwitchFunction: Off-Mom; SymbolCreator: a0799628; VDC_V: 12; Circuit: SPST-NO; Component Kind: Standard; ComponentLink1Description: Datasheet URL; ComponentLink1URL: http://industrial.panasonic.com/www-data/pdf/ATV0000/ATV0000CE10.pdf; CompType: Switch; LatestRevisionDate: 2015-09-10; Library Name: Switches.IntLib; MountType: SMT; Orientation: Side Actuated; PackageReference: Switch, 6.1x1.8x4.6 mm; PartNumber: EVQ-PSD02K; RoHS: Y; Supplier 1: Digi-Key; Supplier Part Number 1: P8088SCT-ND; SymbolVersion: 2013-06-07; Publisher: Texas Instruments
SW2 SW3 = MJTP1117: Switch, SPST-NO, Off-Mom, 1 Pos, 0.05A, 12VDC, TH; Manufacturer: APEM; CurrentRating_mA: 50; PositionCount: 1; SymbolCreator: a0799628; VDC_V: 12; Circuit: SPST-NO; Component Kind: Standard; ComponentLink1Description: Datasheet Link; ComponentLink1URL: http://www.apem.com/files/apem/brochures/MJTP_6MM.pdf; CompType: Switch; LatestRevisionDate: 2016-10-17; Library Name: Switches.IntLib; MountType: TH; Orientation: Side Actuated; PackageReference: 7.3x5.9mm; PartNumber: MJTP1117; RoHS: Y; Supplier 1: Digi-Key; Supplier 2: Mouser; Supplier Part Number 1: 679-2400-ND; Supplier Part Number 2: 642-MJTP1117; SwitchFunction: Off-Mom; SymbolVersion: 2013-06-26; Publisher: Texas Instruments
TP5 = TP_H0.45P0.75: Manufacturer: Texas Instruments; CompType: Pad; ComponentLink1Description: Datasheet Link; ComponentLink1URL: https://sps06.itg.ti.com/sites/KMS/crossdivteams/altium/docs/ComponentDatasheets/testpin with 750um Padsice and 450um drillhole.pdf; Description: Testpoint; LatestRevisionDate: 2016-11-21; Library Name: Hardware.IntLib; MountType: TH; PackageReference: Test Point, 0.45mm hole size; PartNumber: TP_H0.45P0.75; SymbolVersion: 2010-10-20; Publisher: Texas Instruments
U3 = TPS79601DCQR: Ultralow-Noise, High PSRR, Fast, RF, 1A, Low-Dropout Linear Regulator, DCQ0006A; Alternate Manufacturer: Texas Instruments; CompType: IC; SymbolCreator: Altium; U/M: EA; Component Kind: Standard; ComponentLink1Description: Datasheet Link; ComponentLink1URL: http://www.ti.com/lit/ds/symlink/tps79601.pdf; LatestRevisionDate: 2015-02-23; Library Name: TI_Pre_Release.IntLib; Manufacturer: Texas Instruments; MountType: SMT; PackageReference: DCQ0006A; PartNumber: TPS79601DCQR; RoHS: Y; SymbolVersion: 2014-08-28; Publisher: Texas Instruments
